# Altium schematic sheet Main.SchDot(Main)
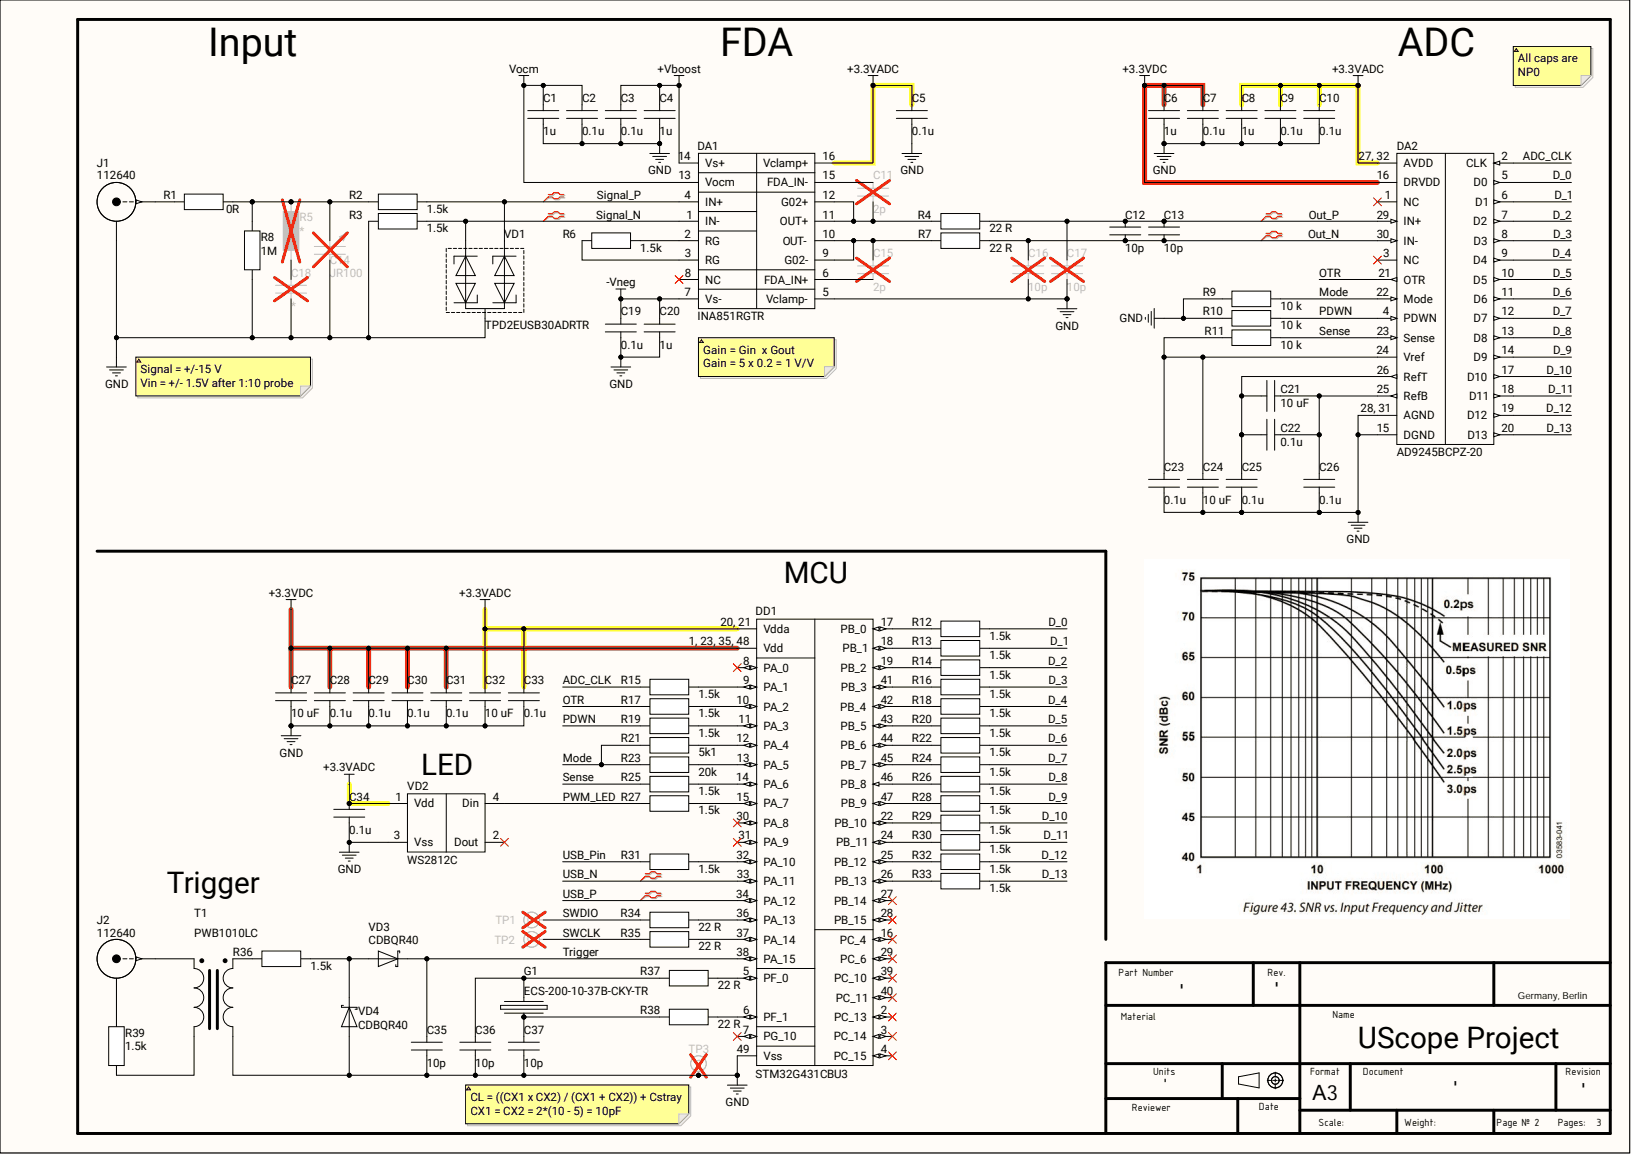
[diagram p0: Main.SchDot(Main)]

## Parts
C1  1u  [C0402]
C2  0.1u  [C0402]
C3  0.1u  [C0402]
C4  1u  [C0402]
C5  0.1u  [C0402]
C6  1u  [C0402]
C7  0.1u  [C0402]
C8  1u  [C0402]
C9  0.1u  [C0402]
C10  0.1u  [C0402]
C11  2p  [C0402]
C12  10p  [C0402]
C13  10p  [C0402]
C14  JR100  [Trimmer Capacitors]
C15  2p  [C0402]
C16  10p  [C0402]
C17  10p  [C0402]
C18  (no value)  [C0603]
C19  0.1u  [C0402]
C20  1u  [C0402]
C21  10 uF  [C0402]
C22  0.1u  [C0402]
C23  0.1u  [C0402]
C24  10 uF  [C0402]
C25  0.1u  [C0402]
C26  0.1u  [C0402]
C27  10 uF  [C0402]
C28  0.1u  [C0402]
C29  0.1u  [C0402]
C30  0.1u  [C0402]
C31  0.1u  [C0402]
C32  10 uF  [C0402]
C33  0.1u  [C0402]
C34  0.1u  [C0402]
C35  10p  [C0402]
C36  10p  [C0402]
C37  10p  [C0402]
DA1  INA851RGTR  [VQFN_16_INA851]
DA2  AD9245BCPZ-20  [LFCSP-32_AD9245]
DD1  STM32G431CBU3  [UFQFPN-48]
G1  ECS-200-10-37B-CKY-TR  [2 mm x 1.6 mm]
J1  112640  [112640]
J2  112640  [112640]
R1  0R  [R0402]
R2  1.5k  [R0402]
R3  1.5k  [R0402]
R4  22 R  [R0402]
R5  (no value)  [R0402]
R6  1.5k  [R0402]
R7  22 R  [R0402]
R8  1M  [R0402]
R9  10 k  [R0402]
R10  10 k  [R0402]
R11  10 k  [R0402]
R12  1.5k  [R0402]
R13  1.5k  [R0402]
R14  1.5k  [R0402]
R15  1.5k  [R0402]
R16  1.5k  [R0402]
R17  1.5k  [R0402]
R18  1.5k  [R0402]
R19  1.5k  [R0402]
R20  1.5k  [R0402]
R21  5k1  [R0402]
R22  1.5k  [R0402]
R23  20k  [R0402]
R24  1.5k  [R0402]
R25  1.5k  [R0402]
R26  1.5k  [R0402]
R27  1.5k  [R0402]
R28  1.5k  [R0402]
R29  1.5k  [R0402]
R30  1.5k  [R0402]
R31  1.5k  [R0402]
R32  1.5k  [R0402]
R33  1.5k  [R0402]
R34  22 R  [R0402]
R35  22 R  [R0402]
R36  1.5k  [R0402]
R37  22 R  [R0402]
R38  22 R  [R0402]
R39  1.5k  [R0402]
T1  PWB1010LC  [PWB1010]
TP1  5117  [36-5117-ND]  (value not drawn)
TP2  5117  [36-5117-ND]  (value not drawn)
TP3  5117  [36-5117-ND]  (value not drawn)
VD1  TPD2EUSB30ADRTR  [SOT-9X3]
VD2  WS2812C  [SMD5050]
VD3  CDBQR40  [0402_VD]
VD4  CDBQR40  [0402_VD]

## Nets
+3.3VADC: C5.2 C8.2 C9.2 C10.2 C32.1 C33.1 C34.1 DA1.16(Vclamp+) DA2.27, 32(AVDD) DD1.20, 21(Vdda) VD2.1(Vdd)
+3.3VDC: C6.2 C7.2 C27.1 C28.1 C29.1 C30.1 C31.1 DA2.16(DRVDD) DD1.1, 23, 35, 48(Vdd)
+Vboost: C3.2 C4.2 DA1.14(Vs+)
-Vneg: C19.2 C20.2 DA1.7(Vs-)
GND: C1.1 C2.1 C3.1 C4.1 C5.1 C6.1 C7.1 C8.1 C9.1 C10.1 C14.2 C16.2 C17.2 C18.2 C19.1 C20.1 C23.1 C24.2 C25.1 C26.1 C27.2 C28.2 C29.2 C30.2 C31.2 C32.2 C33.2 C34.2 C35.2 C36.2 C37.2 DA1.5(Vclamp-) DA2.15(DGND) DA2.28, 31(AGND) DD1.49(Vss) J1.2 R3.1 R8.1 R9.1 R10.1 T1.4 TP3.1 VD1.3 VD2.3(Vss) VD4.1
Vocm: C1.2 C2.2 DA1.13(Vocm)
ADC_CLK: DA2.2(CLK) R15.1
D_0: DA2.5(D0) R12.2
D_1: DA2.6(D1) R13.2
D_2: DA2.7(D2) R14.2
D_3: DA2.8(D3) R16.2
D_4: DA2.9(D4) R18.2
D_5: DA2.10(D5) R20.2
D_6: DA2.11(D6) R22.2
D_7: DA2.12(D7) R24.2
D_8: DA2.13(D8) R26.2
D_9: DA2.14(D9) R28.2
D_10: DA2.17(D10) R29.2
D_11: DA2.18(D11) R30.2
D_12: DA2.19(D12) R32.2
D_13: DA2.20(D13) R33.2
Mode: DA2.22(Mode) R9.2 R21.1 R23.2
OTR: DA2.21(OTR) R17.1
Out_N: C12.2 C13.2 C16.1 DA2.30(IN-) R7.2
Out_P: C12.1 C13.1 C17.1 DA2.29(IN+) R4.2
PDWN: DA2.4(PDWN) R10.2 R19.1
PWM_LED: R27.1 VD2.4(Din)
Sense: DA2.23(Sense) R11.2 R25.1
Signal_N: DA1.1(IN-) R3.2 VD1.2
Signal_P: DA1.4(IN+) R2.2 VD1.1
SWCLK: R35.1 TP2.1
SWDIO: R34.1 TP1.1
Trigger: C35.1 DD1.38(PA_15) VD3.2
USB_N: DD1.33(PA_11)
USB_P: DD1.34(PA_12)
USB_Pin: R31.1
NetC11_1: C11.1 DA1.15(FDA_IN-)
NetC11_2: C11.2 DA1.11(OUT+) DA1.12(G02+) R4.1
NetC14_1: C14.1 R1.1 R2.1 R5.2 R8.2
NetC15_1: C15.1 DA1.9(G02-) DA1.10(OUT-) R7.1
NetC15_2: C15.2 DA1.6(FDA_IN+)
NetC18_1: C18.1 R5.1
NetC21_1: C21.1 C22.2 C25.2 DA2.26(RefT)
NetC21_2: C21.2 C22.1 C26.2 DA2.25(RefB)
NetC23_2: C23.2 C24.1 DA2.24(Vref) R11.1
NetC36_1: C36.1 G1.1 R37.1
NetC37_1: C37.1 G1.3 R38.1
NetDA1_2: DA1.2(RG) R6.2
NetDA1_3: DA1.3(RG) R6.1
NetDD1_5: DD1.5(PF_0) R37.2
NetDD1_6: DD1.6(PF_1) R38.2
NetDD1_9: DD1.9(PA_1) R15.2
NetDD1_10: DD1.10(PA_2) R17.2
NetDD1_11: DD1.11(PA_3) R19.2
NetDD1_12: DD1.12(PA_4) R21.2
NetDD1_13: DD1.13(PA_5) R23.1
NetDD1_14: DD1.14(PA_6) R25.2
NetDD1_15: DD1.15(PA_7) R27.2
NetDD1_17: DD1.17(PB_0) R12.1
NetDD1_18: DD1.18(PB_1) R13.1
NetDD1_19: DD1.19(PB_2) R14.1
NetDD1_22: DD1.22(PB_10) R29.1
NetDD1_24: DD1.24(PB_11) R30.1
NetDD1_25: DD1.25(PB_12) R32.1
NetDD1_26: DD1.26(PB_13) R33.1
NetDD1_32: DD1.32(PA_10) R31.2
NetDD1_36: DD1.36(PA_13) R34.2
NetDD1_37: DD1.37(PA_14) R35.2
NetDD1_41: DD1.41(PB_3) R16.1
NetDD1_42: DD1.42(PB_4) R18.1
NetDD1_43: DD1.43(PB_5) R20.1
NetDD1_44: DD1.44(PB_6) R22.1
NetDD1_45: DD1.45(PB_7) R24.1
NetDD1_46: DD1.46(PB_8) R26.1
NetDD1_47: DD1.47(PB_9) R28.1
NetJ1_1: J1.1 R1.2
NetJ2_1: J2.1 T1.1
NetJ2_2: J2.2 R39.2
NetR36_1: R36.1 T1.6
NetR36_2: R36.2 VD3.1 VD4.2
NetR39_1: R39.1 T1.3

Not connected: DA1 8(NC); DA2 1(NC) 3(NC); DD1 2(PC_13) 3(PC_14) 4(PC_15) 7(PG_10) 8(PA_0) 16(PC_4) 27(PB_14) 28(PB_15) 29(PC_6) 30(PA_8) 31(PA_9) 39(PC_10) 40(PC_11); VD2 2(Dout)

## Library data of the parts
C1 C4 C6 C8 C20 = MLCC: Multilayer Ceramic Capacitors MLCC - SMD/SMT 1 uF 10 VDC 20% 0402 X7S AEC-Q200; Manufacturer: Murata; P/N: GCM155C71A105ME38D; Case: 0402; Component Kind: Standard; Component Type: MLCC; ComponentLink1Description: Link; ComponentLink1URL: https://eu.mouser.com/ProductDetail/Murata-Electronics/GCM155C71A105ME38D?qs=QzBtWTOodeV5ddl%2FvWs3LA%3D%3D; ComponentLink2Description: Datasheet; ComponentLink2URL: https://eu.mouser.com/datasheet/2/281/1/GCM155C71A105ME38_01A-3142248.pdf; Value: 1u; Library Name: Scheet.SchLib
C2 C3 C5 C7 C9 C10 C19 C22 C23 C25 C26 C28-C31 C33 C34 = MLCC: Multilayer Ceramic Capacitors MLCC - SMD/SMT 0.1 uF 50 VDC 20% 0402 X6S; Manufacturer: Murata; P/N: GRM155C81H104ME14D; Case: 0402; Component Kind: Standard; Component Type: MLCC; ComponentLink1Description: Link; ComponentLink2Description: Datasheet; Value: 0.1u; Library Name: Scheet.SchLib
C11-C13 C15-C17 C35-C37 = MLCC: Multilayer Ceramic Capacitors MLCC - SMD/SMT 50V 10pF X7R 0402 10%; Manufacturer: KEMET; P/N: C0402C100K5RACTU; Case: 0402; Component Kind: Standard; Component Type: MLCC; ComponentLink1Description: Link; ComponentLink2Description: Datasheet; Library Name: Scheet.SchLib
  C11 C15: ComponentLink1URL: https://eu.mouser.com/ProductDetail/KEMET/C0402C100K5RACTU?qs=Mv7BduZupUgEdPvwNAxLRQ%3D%3D; ComponentLink2URL: https://eu.mouser.com/datasheet/2/447/KEM_C1002_X7R_SMD-3316098.pdf; Value: 2p
  C12 C13 C37: Value: 10p
  C16 C17 C35 C36: ComponentLink1URL: https://eu.mouser.com/ProductDetail/KEMET/C0402C100K5RACTU?qs=Mv7BduZupUgEdPvwNAxLRQ%3D%3D; ComponentLink2URL: https://eu.mouser.com/datasheet/2/447/KEM_C1002_X7R_SMD-3316098.pdf; Value: 10p
C14 = JR100: Trimmer/Variable Capacitors 2.0 - 10pF 100volts; Manufacturer: Knowles; Value: 2 pF to 10 pF; Case: JR; Component Kind: Standard; Component Type: Trimmer/Variable Capacitors; ComponentLink1Description: Datasheet; ComponentLink1URL: https://eu.mouser.com/datasheet/2/218/Knowles_Trimmers_Catalogue_web-1774092.pdf; ComponentLink2Description: Link; ComponentLink2URL: https://eu.mouser.com/ProductDetail/Knowles-Voltronics/JR100?qs=Xqu1B%2Fs4Uoag80ukOW5org%3D%3D; P/N: JR100; Library Name: Scheet.SchLib
C18 = MLCC: Cercap smd; Component Kind: Standard; ComponentLink1Description: Link; ComponentLink2Description: Datasheet; Library Name: Scheet.SchLib
C21 C24 C27 C32 = MLCC: Multilayer Ceramic Capacitors MLCC - SMD/SMT 10 uF 10 VDC 20% 0402 X5R; Manufacturer: Murata; P/N: GRM155R61A106ME11D; Case: 0402; Component Kind: Standard; Component Type: MLCC; ComponentLink1Description: Link; ComponentLink2Description: Datasheet; Value: 10 uF; Library Name: Scheet.SchLib
  C21: ComponentLink1URL: https://eu.mouser.com/ProductDetail/Murata-Electronics/GRM155R61A106ME11D?qs=xZ%2FP%252Ba9zWqZMJSi57Q8OyA%3D%3D; ComponentLink2URL: https://eu.mouser.com/datasheet/2/281/1/GRM155R61A106ME11_01A-1983731.pdf
DA1 = INA851RGTR: Instrumentation Amplifiers Low-noise (3.2 nV/vHz) high-speed (22 MHz) fully-differential instrumentation amp with OVP (+/-40 V) 16-VQFN -40 to 125; Manufacturer: Texas Instruments; Value: 3.2 nV/vHz. +-40V; Case: VQFN-16; Component Kind: Standard; Component Type: Instrumentation Amplifiers; ComponentLink1Description: Datasheet; ComponentLink1URL: https://www.ti.com/lit/ds/symlink/ina851.pdf?ts=1707581186124&ref_url=https%253A%252F%252Feu.mouser.com%252F; ComponentLink2Description: Link; ComponentLink2URL: https://eu.mouser.com/ProductDetail/Texas-Instruments/INA851RGTR?qs=Y0Uzf4wQF3kbGQkgMC0HWQ%3D%3D; P/N: INA851RGTR; Library Name: Scheet.SchLib
DA2 = AD9245: Analog to Digital Converters - ADC 14-Bit, 20 MSPS/40 MSPS/65 MSPS/80 MSPS 3 V A/D Converter; Manufacturer: Analog Devices Inc.; Value: 14 bit,  20 MS/s; Case: LFCSP-32; Component Kind: Standard; Component Type: Analog to Digital Converters - ADC; ComponentLink1Description: Datasheet; ComponentLink1URL: https://eu.mouser.com/datasheet/2/609/AD9245-3134626.pdf; ComponentLink2Description: Link; ComponentLink2URL: https://eu.mouser.com/ProductDetail/Analog-Devices/AD9245BCPZ-20?qs=%2FtpEQrCGXCyn%2FB%2F5xLQAJw%3D%3D; P/N: AD9245BCPZ-20; Library Name: Scheet.SchLib
DD1 = STM32G431CBU3: ARM Microcontrollers - MCU Mainstream Arm Cortex-M4 MCU 170 MHz 128 Kbytes of Flash Math Accel, Medium Anal; Manufacturer: STMicroelectronics; Value: 170 MHz; Case: UFQFPN-48; Component Kind: Standard; Component Type: ARM Microcontrollers - MCU; ComponentLink1Description: Datasheet; ComponentLink1URL: https://eu.mouser.com/datasheet/2/389/stm32g431c6-1600866.pdf; ComponentLink2Description: Link; ComponentLink2URL: https://eu.mouser.com/ProductDetail/STMicroelectronics/STM32G431CBU3?qs=PzGy0jfpSMuhNWEWgwfZ0w%3D%3D; P/N: STM32G431CBU3; Library Name: Scheet.SchLib
G1 = ECS-200-10-37B-CKY-TR: Crystals 20MHz ESR 70 10pF-30C +85C; Manufacturer: ECS; Value: 20 MHz,  10 PPM; Case: 2 mm x 1.6 mm; Component Kind: Standard; Component Type: Crystals; ComponentLink1Description: Datasheet; ComponentLink1URL: https://eu.mouser.com/datasheet/2/122/ECX_1637B-1633271.pdf; ComponentLink2Description: Link; ComponentLink2URL: https://eu.mouser.com/ProductDetail/ECS/ECS-200-10-37B-CKY-TR?qs=l7cgNqFNU1iRTZcEfH9KUQ%3D%3D; P/N: ECS-200-10-37B-CKY-TR; Library Name: Scheet.SchLib
J1 J2 = 112640: RF Connectors / Coaxial Connectors BNC PCB END LAUNCH 50 OHM RND BODY; Manufacturer: Amphenol; Value: 50 Ohms; Case: BNC; Component Kind: Standard; Component Type: Jack (Socket); ComponentLink1Description: Datasheet; ComponentLink1URL: https://eu.mouser.com/datasheet/2/18/1/amph_s_a0003617014_1-2261107.pdf; ComponentLink2Description: Link; ComponentLink2URL: https://eu.mouser.com/ProductDetail/Amphenol-RF/112640?qs=g6wJ%252BjiQWOckvE%2FP9L4wAw%3D%3D; P/N: 112640; Library Name: Scheet.SchLib
R1 R5 R8 = SMD Resistor: Res SMD; Component Kind: Standard; ComponentLink1Description: Datasheet; ComponentLink2Description: Link; Library Name: Scheet.SchLib
  R1: Value: 0R
  R8: Value: 1M
R2 R3 R6 R12-R20 R22-R33 R36 R39 = SMD Resistor: Thick Film Resistors - SMD 0402 1K0 0.5%; Case: 0402; Component Kind: Standard; ComponentLink1Description: Datasheet; ComponentLink1URL: https://eu.mouser.com/datasheet/2/210/kamaya_products_RGC__english_20170424_101024-1651573.pdf; ComponentLink2Description: Link; ComponentLink2URL: https://eu.mouser.com/ProductDetail/Kamaya/RGC1-16SC1001DTH?qs=KAq9QXcE8HAz3iV8i32W5A%3D%3D; Library Name: Scheet.SchLib
  R2 R3 R6 R12-R20 R22 R24-R33 R36 R39: Value: 1.5k
  R23: Value: 20k
R4 R7 R34 R35 R37 R38 = SMD Resistor: Res SMD; Manufacturer: Panasonic; P/N: ERJ-2GEJ220X; Case: 0402; Component Kind: Standard; ComponentLink1Description: Datasheet; ComponentLink2Description: Link; Value: 22 R; Library Name: Scheet.SchLib
R9-R11 R21 = SMD Resistor: Thick Film Resistors - SMD 0402 10Kohms 0.5%AEC-Q200; Manufacturer: Panasonic; P/N: ERJ-2RHD103X; Case: 0402; Component Kind: Standard; ComponentLink1Description: Datasheet; ComponentLink1URL: https://eu.mouser.com/datasheet/2/315/AOA0000C304-1149620.pdf; ComponentLink2Description: Link; ComponentLink2URL: https://eu.mouser.com/ProductDetail/Panasonic/ERJ-2RKF2202X?qs=sGAEpiMZZMvdGkrng054t8AJgcdMkx7xGCv6QlnvaAM%3D; Library Name: Scheet.SchLib
  R9-R11: Value: 10 k
  R21: Value: 5k1
T1 = PWB1010L: Audio Transformers / Signal Transformers PWB Wideband RF 1:1 0.0035-125 MHz; Manufacturer: Coilcraft; Value: 3.5 kHz to 125 MHz; Component Kind: Standard; ComponentLink1Description: Datasheet; ComponentLink1URL: https://eu.mouser.com/datasheet/2/597/pwb-463529.pdf; ComponentLink2Description: Link; ComponentLink2URL: https://eu.mouser.com/ProductDetail/Coilcraft/PWB1010LC?qs=ZYnrCdKdyeesEVMGru6ImA%3D%3D; P/N: PWB1010LC; Library Name: Scheet.SchLib
TP1-TP3 = (no symbol name): PCB TEST POINT BLUE; Manufacturer: Keystone Electronics; Value: 36-5117; Case: THT; Component Kind: Standard; Component Type: Test point; ComponentLink1Description: Datasheet; ComponentLink1URL: https://ru.mouser.com/datasheet/2/215/5115_5119-744073.pdf; ComponentLink2Description: Link; ComponentLink2URL: https://ru.mouser.com/ProductDetail/Keystone-Electronics/5117?qs=%2Fha2pyFadujSuVybhyFc6oqj%252BXCGMBBx2uPmIz4OBqU%3D; Library Name: Others.SchLib; P/N: 5117
VD1 = TPD2EUSB30ADRTR: ESD Suppressors / TVS Diodes 2 Channel ESD/ Surge Solution; Manufacturer: Texas Instruments; Value: 2 Channel, 4.5 V; Case: SOT-9X3; Component Kind: Standard; Component Type: ESD Suppressors / TVS Diodes; ComponentLink1Description: Datasheet; ComponentLink1URL: https://www.ti.com/lit/ds/symlink/tpd2eusb30.pdf?ts=1708175608995&ref_url=https%253A%252F%252Feu.mouser.com%252F; ComponentLink2Description: Link; ComponentLink2URL: https://eu.mouser.com/ProductDetail/Texas-Instruments/TPD2EUSB30ADRTR?qs=O1HRStiETCgOfMa6oTfOlg%3D%3D; P/N: TPD2EUSB30ADRTR; Library Name: Scheet.SchLib
VD2 = WS2812: RGB SMD5050 Light Emitting Diodes (LED) ROHS; Manufacturer: Worldsemi; Case: SMD5050; Component Kind: Standard; Component Type: RGB LED; ComponentLink1Description: Datasheet; ComponentLink1URL: https://datasheet.lcsc.com/lcsc/1810231210_Worldsemi-WS2812C_C114587.pdf; ComponentLink2Description: Link; ComponentLink2URL: https://www.lcsc.com/product-detail/Light-Emitting-Diodes-LED_Worldsemi-WS2812C_C114587.html; P/N: WS2812C; Value: RGB; Library Name: Scheet.SchLib
VD3 VD4 = CDBQR40: Schottky Diodes & Rectifiers 200mA 40V DFN Sm. Sgnl. Schottky; Manufacturer: Comchip Technology; Value: 200mA, 40V; Case: 0402; Component Kind: Standard; Component Type: Schottky Diodes & Rectifiers; ComponentLink1Description: Datasheet; ComponentLink1URL: https://eu.mouser.com/datasheet/2/80/CDBQR40_HF_RevC811666-2488328.pdf; ComponentLink2Description: Link; ComponentLink2URL: https://eu.mouser.com/ProductDetail/Comchip-Technology/CDBQR40?qs=tw%252BuQ%2FB6PO2qnzfzuJamKw%3D%3D; P/N: CDBQR40; Library Name: Scheet.SchLib
